# Altium schematic sheet hv_io_connector.SchDoc
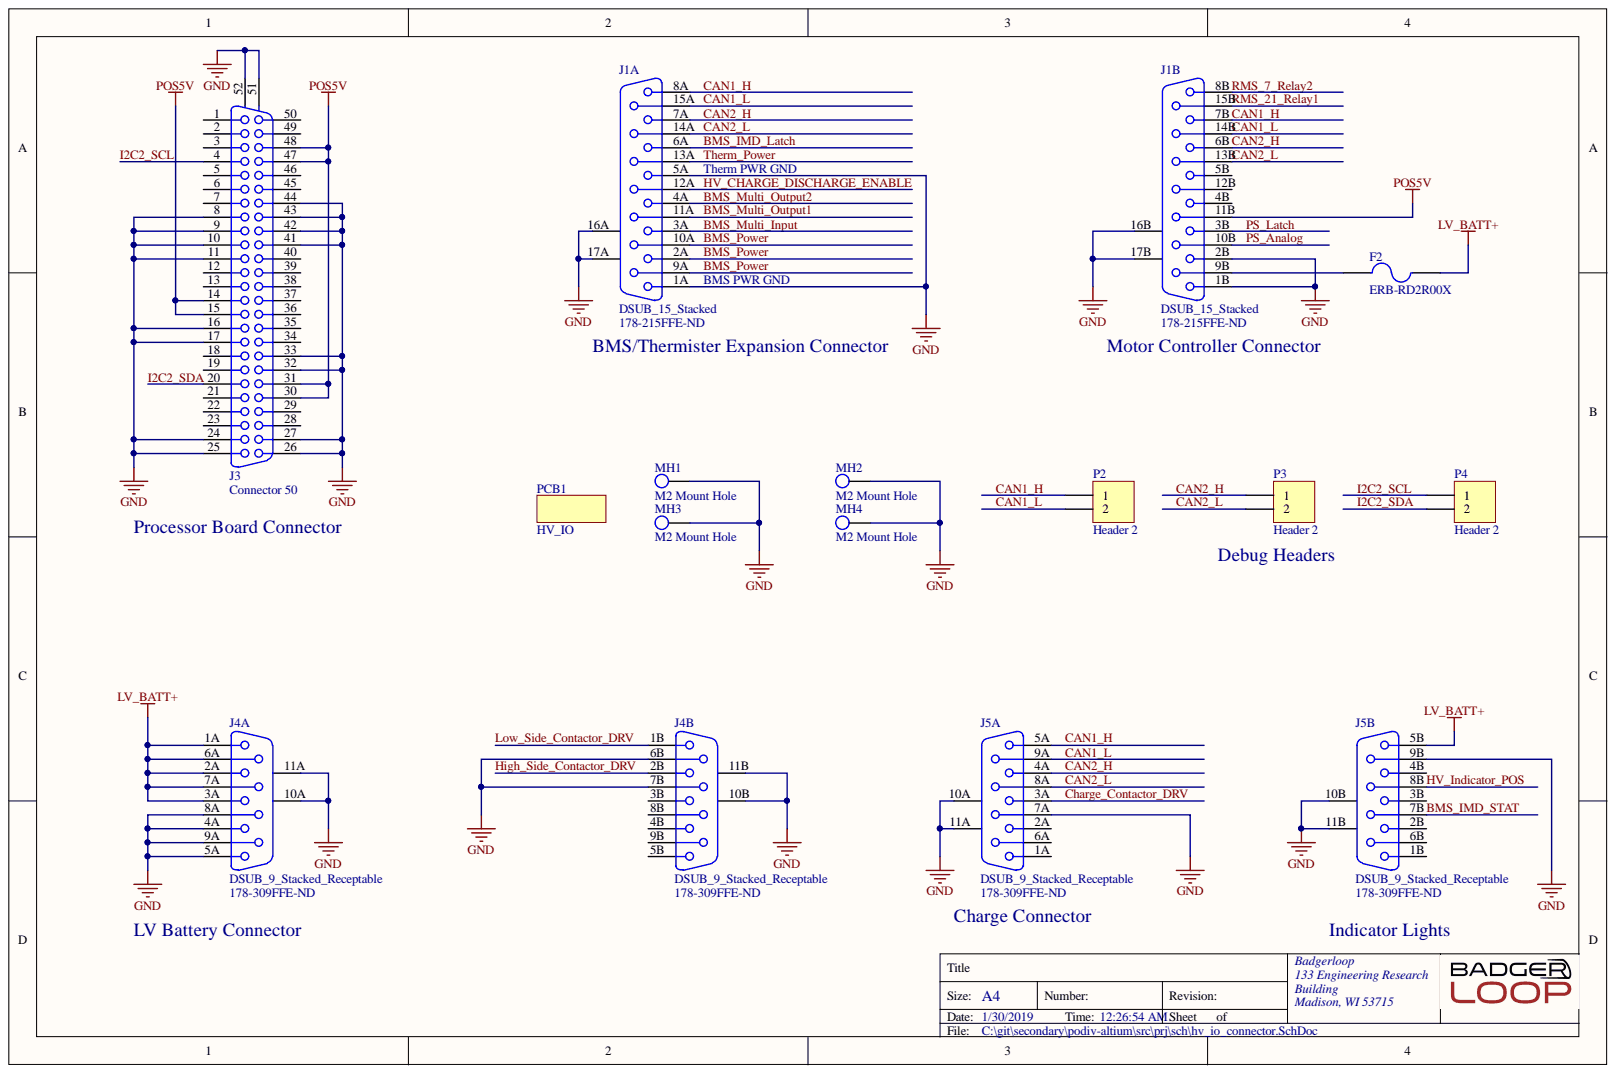
[diagram p0: hv_io_connector.SchDoc]

## Parts
F2  ERB-RD2R00X  [SMD-0402-RES]
J1  DSUB_15_Stacked  Current Rating: 5A  Supplier Part Number 1: 178-215FFE-ND  [DSUB_15_Stacked]  (parts A,B)
J3  Connector 50  [050DSUB1.27-H96]
J4  DSUB_9_Stacked_Receptable  Current Rating: 5A  Supplier Part Number 1: 178-309FFE-ND  [DSUB_9_Stacked]  (parts A,B)
J5  DSUB_9_Stacked_Receptable  Current Rating: 5A  Supplier Part Number 1: 178-309FFE-ND  [DSUB_9_Stacked]  (parts A,B)
MH1  M2 Mount Hole  [MH]
MH2  M2 Mount Hole  [MH]
MH3  M2 Mount Hole  [MH]
MH4  M2 Mount Hole  [MH]
P2  Header 2  [Pin Header 1x2 TH Pitch 2.54mm]
P3  Header 2  [Pin Header 1x2 TH Pitch 2.54mm]
P4  Header 2  [Pin Header 1x2 TH Pitch 2.54mm]
PCB1  HV_IO  [PCB]

## Nets
GND: J1.1A J1.1B J1.2B J1.5A J1.16A J1.16B J1.17A J1.17B J3.8 J3.9 J3.10 J3.11 J3.16 J3.17 J3.24 J3.25 J3.26 J3.27 J3.32 J3.33 J3.41 J3.42 J3.43 J3.44 J3.51 J3.52 J4.4A J4.5A J4.6B J4.7B J4.8A J4.9A J4.10A J4.10B J4.11A J4.11B J5.7A J5.9B J5.10A J5.10B J5.11A J5.11B MH1.1 MH2.1 MH3.1 MH4.1
LV_BATT+: F2.2 J4.1A J4.2A J4.3A J4.6A J4.7A J5.5B
POS5V: J1.11B J3.14 J3.15 J3.30 J3.31 J3.47 J3.48
BMS_IMD_Latch: J1.6A
BMS_IMD_STAT: J5.7B
BMS_Multi_Input: J1.3A
BMS_Multi_Output1: J1.11A
BMS_Multi_Output2: J1.4A
BMS_Power: J1.2A J1.9A J1.10A
CAN1_H: J1.7B J1.8A J5.5A P2.1
CAN1_L: J1.14B J1.15A J5.9A P2.2
CAN2_H: J1.6B J1.7A J5.4A P3.1
CAN2_L: J1.13B J1.14A J5.8A P3.2
Charge_Contactor_DRV: J5.3A
High_Side_Contactor_DRV: J4.2B
HV_CHARGE_DISCHARGE_ENABLE: J1.12A
HV_Indicator_POS: J5.8B
I2C2_SCL: J3.4 P4.1
I2C2_SDA: J3.20 P4.2
Low_Side_Contactor_DRV: J4.1B
PS_Analog: J1.10B
PS_Latch: J1.3B
RMS_7_Relay2: J1.8B
RMS_21_Relay1: J1.15B
Therm_Power: J1.13A
NetF2_1: F2.1 J1.9B

Not connected: J1 4B 5B 12B; J3 1 2 3 5 6 7 12 13 18 19 21 22 23 28 29 34 35 36 37 38 39 40 45 46 49 50; J4 3B 4B 5B 8B 9B; J5 1A 1B 2A 2B 3B 4B 6A 6B

## Library data of the parts
F2 = ERB-RD2R00X: FUSE BOARD MOUNT 2A 32VDC 0402; Manufacturer: Panasonic; Component Kind: Standard; Digikey Link: https://www.digikey.com/product-detail/en/panasonic-electronic-components/ERB-RD2R00X/P15123TR-ND/2796785; Digikey P/N: P15123TR-ND; Library Name: temp.SchLib; Manufacturer P/N: ERB-RD2R00X
J1 = DSUB_15_Stacked: 15, 15 Position D-Sub - Stacked Receptacle, Female Sockets Connector, Board Edge, Through Hole, Right Angle Solder; Manufacturer: NorComp; Manufacturer Part Number: 178-015-513R491; Body Material: Steel; Connector Type: D-Sub,Receptacle,Signal; Number of Contacts: 30; Color: Black; Component Kind: Standard; ComponentLink1Description: https://www.norcomp.net/rohspdfs/Connectors/17Y/178/513/178-YYY-513R491.pdf; ComponentLink1URL: https://www.norcomp.net/rohspdfs/Connectors/17Y/178/513/178-YYY-513R491.pdf; ComponentLink2Description: http://www.norcomp.net/rohspdfs/Connectors/17Y/178/513/178-YYY-513R491.pdf; ComponentLink2URL: http://www.norcomp.net/rohspdfs/Connectors/17Y/178/513/178-YYY-513R491.pdf; Contact Material: Brass; Contact Plating: Gold; Features: Shielded; Gender: Female; Housing Material: Nylon; Lead Free: Lead Free; Library Name: temp.SchLib; Max Operating Temperature: 105°C; Min Operating Temperature: -55°C; Mount: Edge,Through Hole; Package Quantity: 35; Packaging: Tray; Pricing 1: 1 = 8.81000, 10 = 8.42600, 35 = 7.66000, 70 = 7.27700, 105 = 7.08552, 280 = 6.31950, 525 = 5.93650, 1015 = 5.24710 (USD); REACH SVHC: No SVHC; RoHS: Yes; Stock 1: 2348; Supplier 1: Digi-Key; Termination: Solder
J3 = Connector 50: Plug Header Assembly; Manufacturer: HARTING; Manufacturer P/N: 09665627811; Component Kind: Standard; Digikey Link: https://www.digikey.com/product-detail/en/harting/09665627811/1195-5057-ND/4322749; Digikey P/N: 1195-5057-ND; Library Name: shelby_temp.SchLib
J4 J5 = DSUB_9_Stacked_Receptable: 9, 9 Position D-Sub - Stacked Receptacle, Female Sockets Connector, Board Edge, Through Hole, Right Angle Solder; Manufacturer: NorComp; Manufacturer Part Number: 178-009-513R691; Connector Type: D-Sub,Receptacle,Signal; Gender: Female to Female; Number of Contacts: 9; Number of Ports: 2; Plating: Nickel; Color: Black; Component Kind: Standard; ComponentLink1Description: http://www.norcomp.net/rohspdfs/Connectors/17Y/178/513/178-YYY-513R691.pdf; ComponentLink1URL: http://www.norcomp.net/rohspdfs/Connectors/17Y/178/513/178-YYY-513R691.pdf; Contact Material: Brass; Contact Plating: Gold; Features: Shielded; Housing Material: Nylon; Lead Free: Lead Free; Library Name: temp.SchLib; Max Operating Temperature: 105°C; Min Operating Temperature: -55°C; Mount: Edge,Through Hole; Package Quantity: 45; Packaging: Tray; Pricing 1: 1 = 7.32000, 10 = 7.01500, 45 = 6.40511, 90 = 6.10000, 135 = 5.79504, 270 = 5.18500, 540 = 4.88000, 1035 = 4.27000 (USD); RoHS: Yes; Shell Material: Steel; Stock 1: 1545; Supplier 1: Digi-Key; Termination: Solder
P2-P4 = Header 2: Header, 2-Pin; Component Kind: Standard; LatestRevisionDate: 17-Jul-2002; LatestRevisionNote: Re-released for DXP Platform.; Library Name: connector.SchLib; Signal Integrity: Connector; Publisher: Altium Limited
PCB1 = PCB: Component Kind: Standard; Library Name: temp.SchLib
MH1-MH4 = M2 Mount Hole: Component Kind: Standard; Description: M2 Mount Hole
